# Altium schematic sheet S1216_V1.1.SchDoc
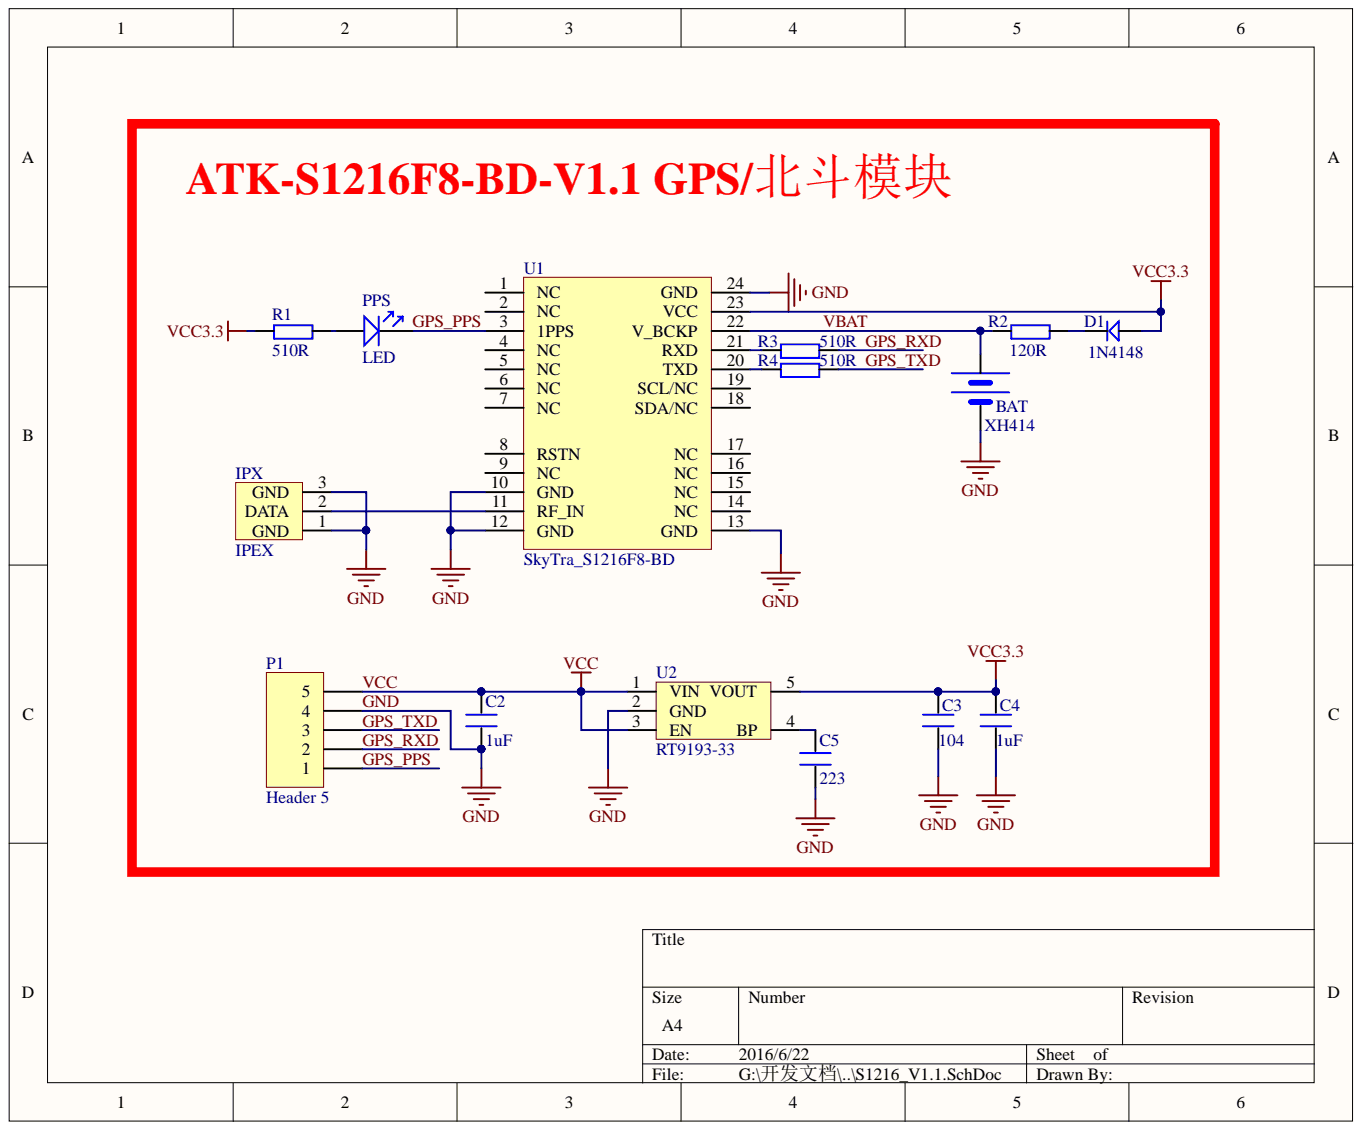
[diagram p0: S1216_V1.1.SchDoc]

## Parts
BAT  XH414  [XH414]
C2  1uF  [0603P]
C3  104  [0603P]
C4  1uF  [0603P]
C5  223  [0603P]
D1  1N4148  [DIODE_SOP]
IPX  IPEX  [IPEX]
P1  Header 5  [HDR1X5]
PPS  LED  [SMDLED]
R1  510R  [0603P]
R2  120R  [0603P]
R3  510R  [0603P]
R4  510R  [0603P]
U1  SkyTra_S1216F8-BD  [GPS_NEO-6]
U2  RT9193-33  [SOT-23-5]

## Nets
VCC3.3: C3.2 C4.2 D1.1 R1.1 U1.23(VCC) U2.5(VOUT)
GND: BAT.2 C2.1 C3.1 C4.1 C5.1 IPX.1 IPX.3 P1.4 U1.10(GND) U1.12(GND) U1.13(GND) U1.24(GND) U2.2(GND)
GPS_PPS: P1.1 PPS.2 U1.3(1PPS)
GPS_RXD: P1.2 R3.2
GPS_TXD: P1.3 R4.2
VBAT: BAT.1 R2.1 U1.22(V_BCKP)
VCC: C2.2 P1.5 U2.1(VIN) U2.3(EN)
NetC5_2: C5.2 U2.4(BP)
NetD1_2: D1.2 R2.2
NetIPX_2: IPX.2 U1.11(RF_IN)
NetPPS_1: PPS.1 R1.2
NetR3_1: R3.1 U1.21(RXD)
NetR4_1: R4.1 U1.20(TXD)
N00005:

Not connected: U1 1(NC) 2(NC) 4(NC) 5(NC) 6(NC) 7(NC) 8(RSTN) 9(NC) 14(NC) 15(NC) 16(NC) 17(NC) 18(SDA/NC) 19(SCL/NC)

## Library data of the parts
BAT = XH414: XH414 IV01E; Component Kind: Standard; Library Name: ATK-NEO-6M-V2.3.IntLib
C2-C5 = C: Component Kind: Standard; Library Name: ATK-NEO-6M-V2.3.IntLib
D1 = D: Component Kind: Standard; Description: 1N4148; Library Name: ATK-NEO-6M-V2.3.IntLib
IPX = IPEX: IPEX座; Component Kind: Standard; Library Name: ATK-NEO-6M-V2.3.IntLib
P1 = Header 5: Header, 5-Pin; Component Kind: Standard; LatestRevisionDate: 17-Jul-2002; LatestRevisionNote: Re-released for DXP Platform.; Library Name: ATK-NEO-6M-V2.3.IntLib; Signal Integrity: Connector; Publisher: Altium Limited
R1-R4 = R: Component Kind: Standard; Library Name: ATK-NEO-6M-V2.3.IntLib
U1 = SkyTra_GPS: Component Kind: Standard; Library Name: ad_lib.SchLib
U2 = RT9193-33: 3.3V超低压差LDO; Component Kind: Standard; Library Name: ATK-NEO-6M-V2.3.IntLib
PPS = LED: Component Kind: Standard; Library Name: ATK-NEO-6M-V2.3.IntLib
